# Altium schematic sheet 09 - FPGA POWER.SchDoc
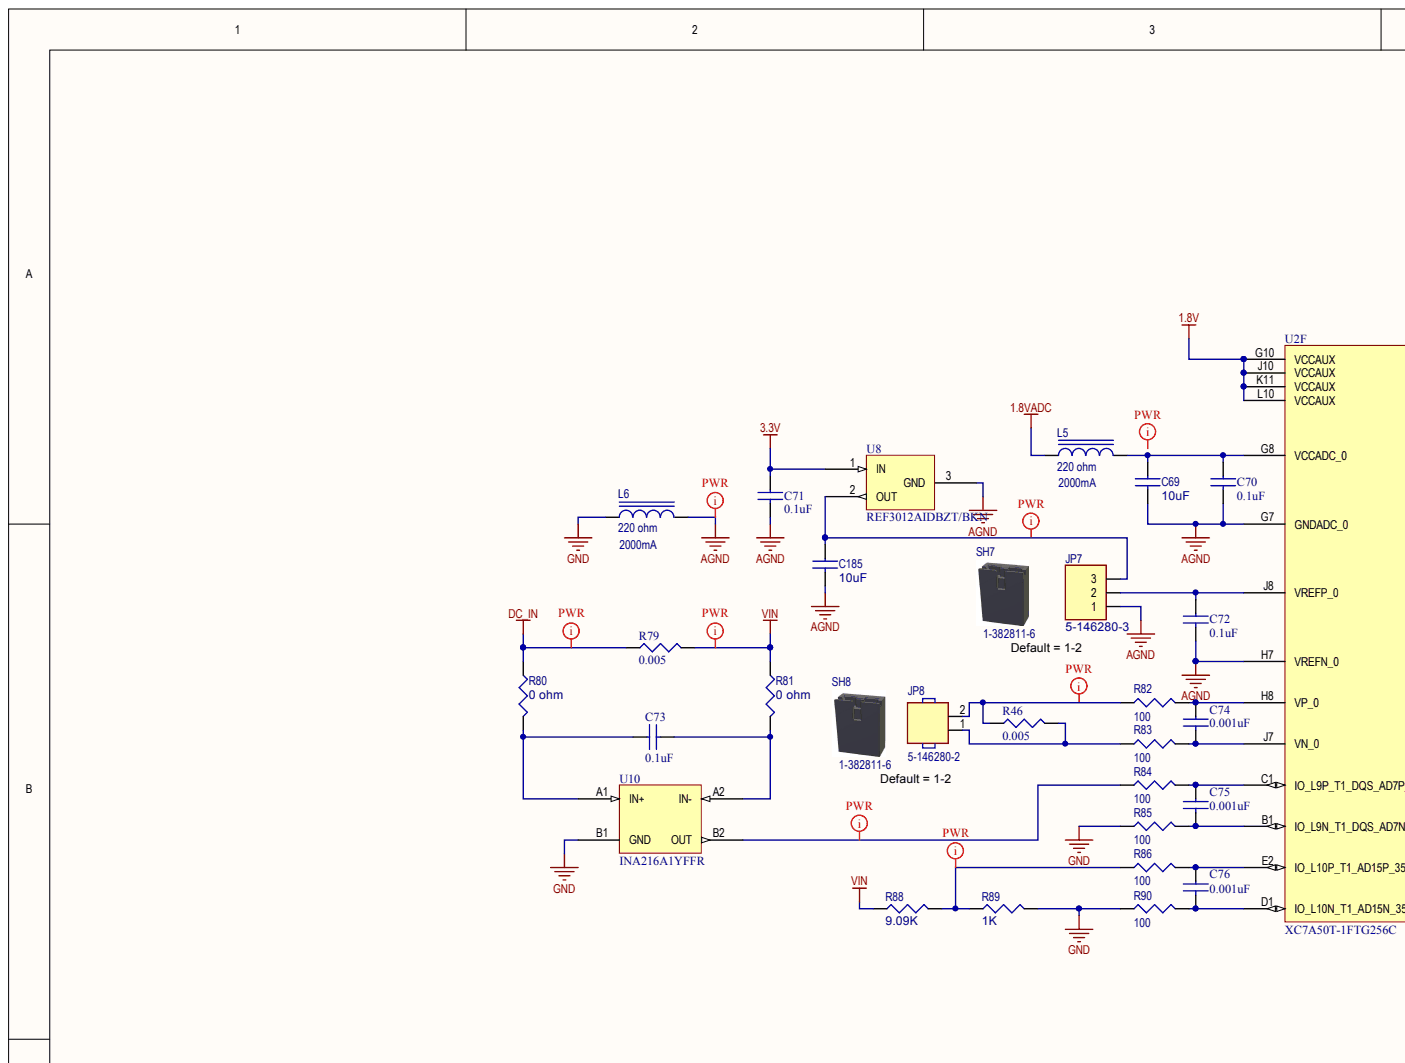
[diagram p0: 09 - FPGA POWER.SchDoc, top left]
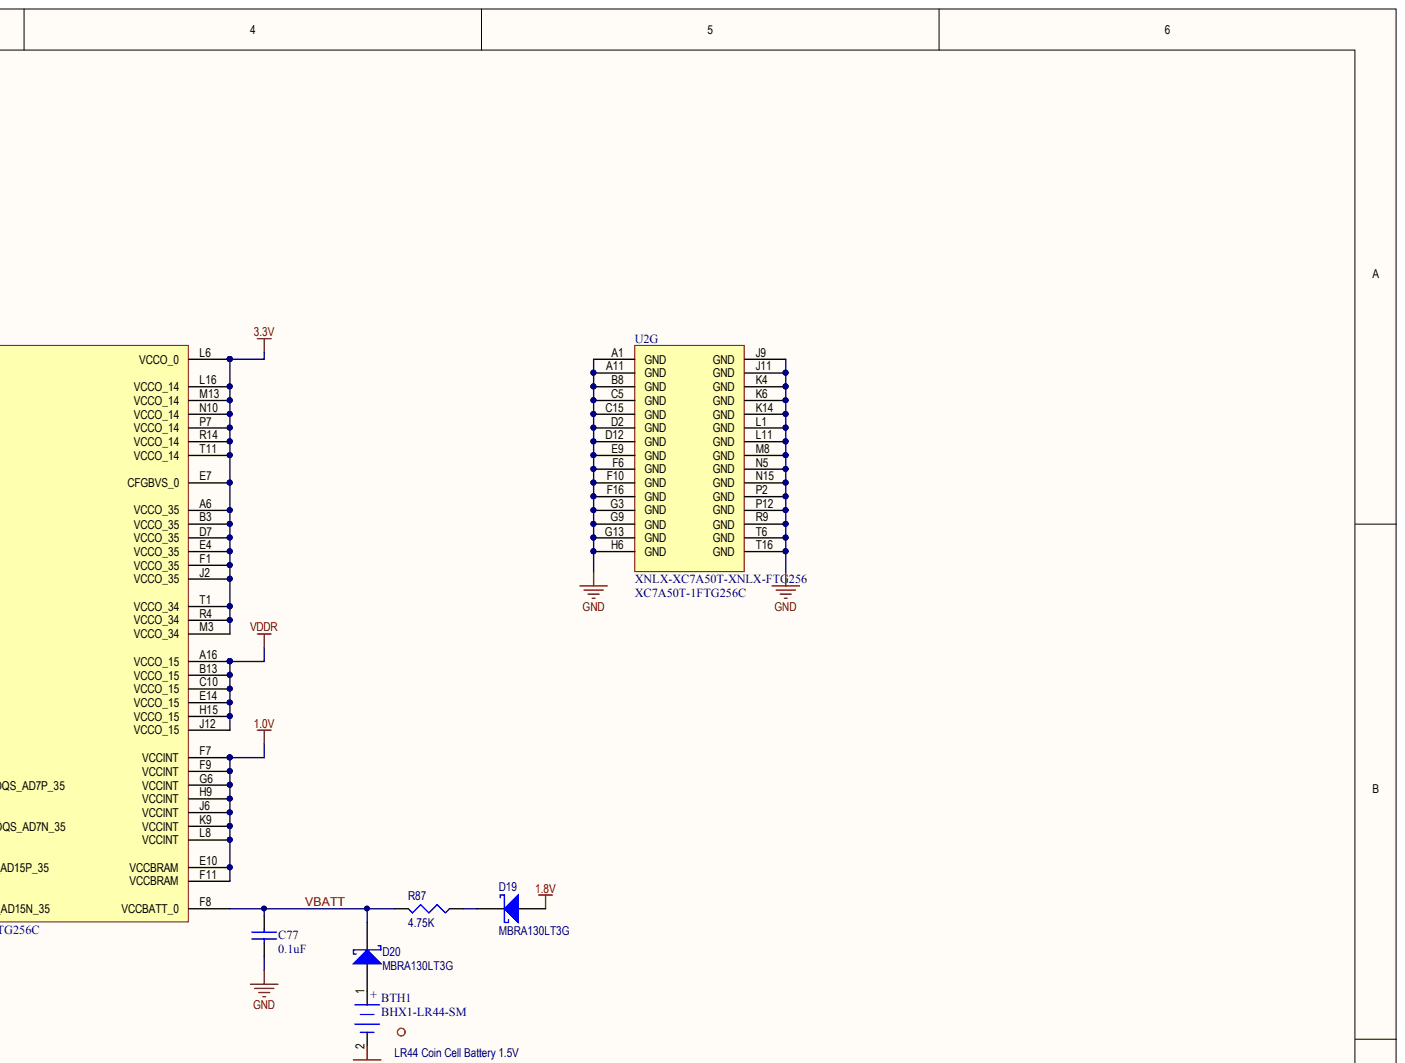
[diagram p1: 09 - FPGA POWER.SchDoc, top right]
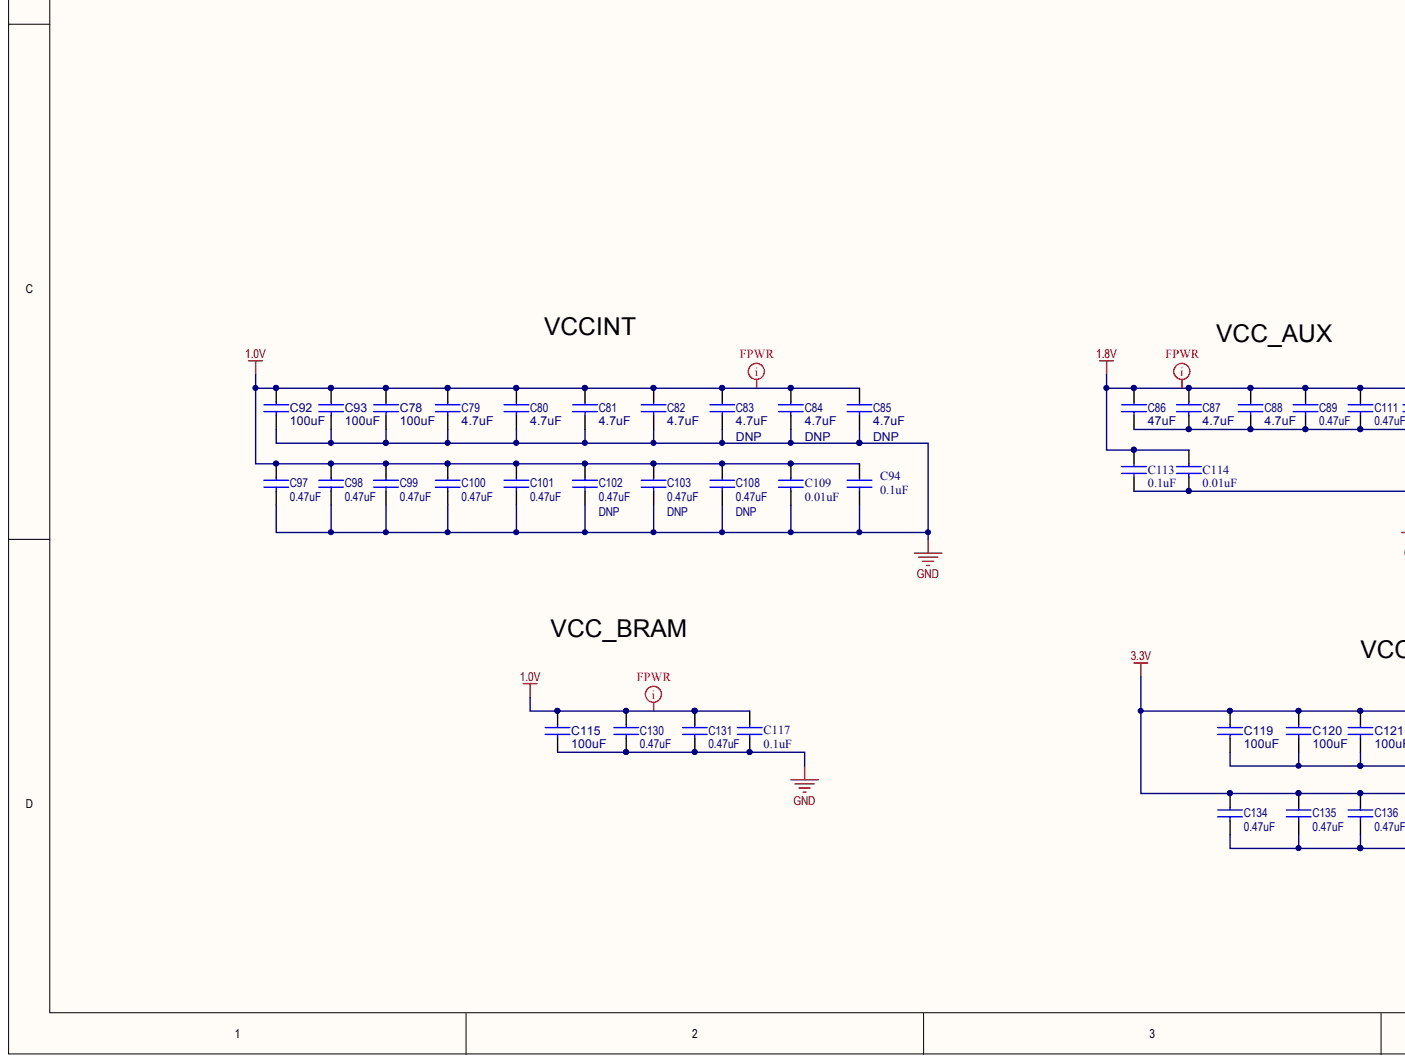
[diagram p2: 09 - FPGA POWER.SchDoc, bottom left]
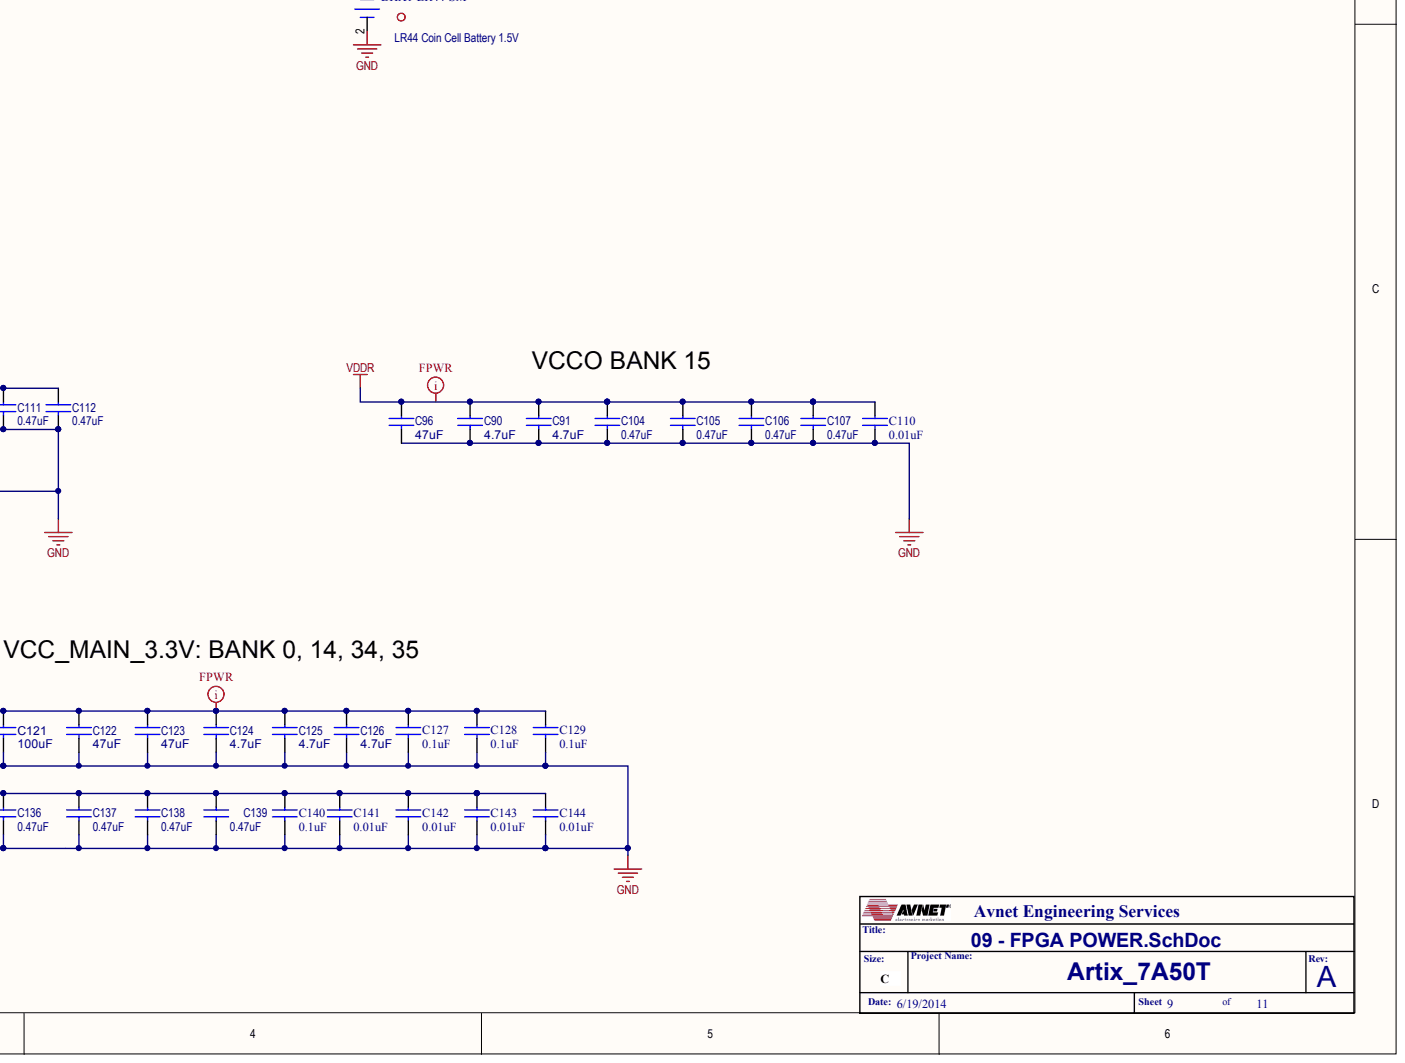
[diagram p3: 09 - FPGA POWER.SchDoc, bottom right]

## Parts
BPH1  LR44 Coin Cell Battery 1.5V
BTH1  BHX1-LR44-SM
C69  10uF
C70  value 0.1uF  (hidden comment: PC522)
C71  value 0.1uF  (hidden comment: PC522)
C72  value 0.1uF  (hidden comment: PC522)
C73  value 0.1uF  (hidden comment: PC522)
C74  value 0.001uF  (hidden comment: 0.001uF 6.3V 0201)
C75  value 0.001uF  (hidden comment: 0.001uF 6.3V 0201)
C76  value 0.001uF  (hidden comment: 0.001uF 6.3V 0201)
C77  value 0.1uF  (hidden comment: PC522)
C78  100uF
C79  value 4.7uF  (hidden comment: 4.8uF)
C80  value 4.7uF  (hidden comment: 4.8uF)
C81  value 4.7uF  (hidden comment: 4.8uF)
C82  value 4.7uF  (hidden comment: 4.8uF)
C83  value 4.7uF  (hidden comment: 4.8uF)
C84  value 4.7uF  (hidden comment: 4.8uF)
C85  value 4.7uF  (hidden comment: 4.8uF)
C86  47uF
C87  value 4.7uF  (hidden comment: 4.8uF)
C88  value 4.7uF  (hidden comment: 4.8uF)
C89  value 0.47uF  (hidden comment: PC475)
C90  value 4.7uF  (hidden comment: 4.8uF)
C91  value 4.7uF  (hidden comment: 4.8uF)
C92  100uF
C93  100uF
C94  value 0.1uF  (hidden comment: PC522)
C96  47uF
C97  value 0.47uF  (hidden comment: PC475)
C98  value 0.47uF  (hidden comment: PC475)
C99  value 0.47uF  (hidden comment: PC475)
C100  value 0.47uF  (hidden comment: PC475)
C101  value 0.47uF  (hidden comment: PC475)
C102  value 0.47uF  (hidden comment: PC475)
C103  value 0.47uF  (hidden comment: PC475)
C104  value 0.47uF  (hidden comment: PC475)
C105  value 0.47uF  (hidden comment: PC475)
C106  value 0.47uF  (hidden comment: PC475)
C107  value 0.47uF  (hidden comment: PC475)
C108  value 0.47uF  (hidden comment: PC475)
C109  value 0.01uF  (hidden comment: PC003)
C110  value 0.01uF  (hidden comment: PC003)
C111  value 0.47uF  (hidden comment: PC475)
C112  value 0.47uF  (hidden comment: PC475)
C113  value 0.1uF  (hidden comment: PC522)
C114  value 0.01uF  (hidden comment: PC003)
C115  100uF
C117  value 0.1uF  (hidden comment: PC522)
C119  100uF
C120  100uF
C121  100uF
C122  47uF
C123  47uF
C124  value 4.7uF  (hidden comment: 4.8uF)
C125  value 4.7uF  (hidden comment: 4.8uF)
C126  value 4.7uF  (hidden comment: 4.8uF)
C127  value 0.1uF  (hidden comment: PC522)
C128  value 0.1uF  (hidden comment: PC522)
C129  value 0.1uF  (hidden comment: PC522)
C130  value 0.47uF  (hidden comment: PC475)
C131  value 0.47uF  (hidden comment: PC475)
C134  value 0.47uF  (hidden comment: PC475)
C135  value 0.47uF  (hidden comment: PC475)
C136  value 0.47uF  (hidden comment: PC475)
C137  value 0.47uF  (hidden comment: PC475)
C138  value 0.47uF  (hidden comment: PC475)
C139  value 0.47uF  (hidden comment: PC475)
C140  value 0.1uF  (hidden comment: PC522)
C141  value 0.01uF  (hidden comment: PC003)
C142  value 0.01uF  (hidden comment: PC003)
C143  value 0.01uF  (hidden comment: PC003)
C144  value 0.01uF  (hidden comment: PC003)
C185  10uF
D19  Device: MBRA130LT3G  (hidden comment: DD004)
D20  Device: MBRA130LT3G  (hidden comment: DD004)
JP7  Device: 5-146280-3  (hidden comment: EH003)
JP8  5-146280-2
L5  value 220 ohm  Max I: 2000mA  (hidden comment: PF009)
L6  value 220 ohm  Max I: 2000mA  (hidden comment: PF009)
R46  value 0.005  (hidden comment: RES 1206 0.005)
R79  value 0.005  (hidden comment: RES 1206 0.005)
R80  0 ohm
R81  0 ohm
R82  value 100  (hidden comment: 0402)
R83  value 100  (hidden comment: 0402)
R84  value 100  (hidden comment: 0402)
R85  value 100  (hidden comment: 0402)
R86  value 100  (hidden comment: 0402)
R87  value 4.75K  (hidden comment: 0402)
R88  9.09K
R89  1K
R90  value 100  (hidden comment: 0402)
SH7  1-382811-6
SH8  1-382811-6
U2  XNLX-XC7A50T-XNLX-FTG256  MFGPN: XC7A50T-1FTG256C  (parts F,G)
U8  MFGPN: REF3012AIDBZT/BKN  (hidden comment: TI REF3012)
U10  MFGPN: INA216A1YFFR  (hidden comment: TI INA216A1)

## Nets
1.0V: C78.1 C79.1 C80.1 C81.1 C82.1 C83.1 C84.1 C85.1 C92.1 C93.1 C94.1 C97.1 C98.1 C99.1 C100.1 C101.1 C102.1 C103.1 C108.1 C109.1 C115.1 C117.1 C130.1 C131.1 U2.E10(VCCBRAM) U2.F7(VCCINT) U2.F9(VCCINT) U2.F11(VCCBRAM) U2.G6(VCCINT) U2.H9(VCCINT) U2.J6(VCCINT) U2.K9(VCCINT) U2.L8(VCCINT)
1.8V: C86.1 C87.1 C88.1 C89.1 C111.1 C112.1 C113.1 C114.1 D19.A U2.G10(VCCAUX) U2.J10(VCCAUX) U2.K11(VCCAUX) U2.L10(VCCAUX)
1.8VADC: L5.1
3.3V: C71.1 C119.1 C120.1 C121.1 C122.1 C123.1 C124.1 C125.1 C126.1 C127.1 C128.1 C129.1 C134.1 C135.1 C136.1 C137.1 C138.1 C139.1 C140.1 C141.1 C142.1 C143.1 C144.1 U2.A6(VCCO_35) U2.B3(VCCO_35) U2.D7(VCCO_35) U2.E4(VCCO_35) U2.E7(CFGBVS_0) U2.F1(VCCO_35) U2.J2(VCCO_35) U2.L6(VCCO_0) U2.L16(VCCO_14) U2.M3(VCCO_34) U2.M13(VCCO_14) U2.N10(VCCO_14) U2.P7(VCCO_14) U2.R4(VCCO_34) U2.R14(VCCO_14) U2.T1(VCCO_34) U2.T11(VCCO_14) U8.1
AGND: C69.2 C70.2 C71.2 C72.2 C185.2 JP7.1 L6.2 U2.G7(GNDADC_0) U2.H7(VREFN_0) U8.3
DC_IN: R79.1 R80.1
GND: BTH1.2 C77.2 C78.2 C79.2 C80.2 C81.2 C82.2 C83.2 C84.2 C85.2 C86.2 C87.2 C88.2 C89.2 C90.2 C91.2 C92.2 C93.2 C94.2 C96.2 C97.2 C98.2 C99.2 C100.2 C101.2 C102.2 C103.2 C104.2 C105.2 C106.2 C107.2 C108.2 C109.2 C110.2 C111.2 C112.2 C113.2 C114.2 C115.2 C117.2 C119.2 C120.2 C121.2 C122.2 C123.2 C124.2 C125.2 C126.2 C127.2 C128.2 C129.2 C130.2 C131.2 C134.2 C135.2 C136.2 C137.2 C138.2 C139.2 C140.2 C141.2 C142.2 C143.2 C144.2 L6.1 R85.1 R89.1 R90.1 U2.A1(GND) U2.A11(GND) U2.B8(GND) U2.C5(GND) U2.C15(GND) U2.D2(GND) U2.D12(GND) U2.E9(GND) U2.F6(GND) U2.F10(GND) U2.F16(GND) U2.G3(GND) U2.G9(GND) U2.G13(GND) U2.H6(GND) U2.J9(GND) U2.J11(GND) U2.K4(GND) U2.K6(GND) U2.K14(GND) U2.L1(GND) U2.L11(GND) U2.M8(GND) U2.N5(GND) U2.N15(GND) U2.P2(GND) U2.P12(GND) U2.R9(GND) U2.T6(GND) U2.T16(GND) U10.B1(GND)
VDDR: C90.1 C91.1 C96.1 C104.1 C105.1 C106.1 C107.1 C110.1 U2.A16(VCCO_15) U2.B13(VCCO_15) U2.C10(VCCO_15) U2.E14(VCCO_15) U2.H15(VCCO_15) U2.J12(VCCO_15)
VIN: R79.2 R81.1 R88.1
VBATT: C77.1 D20.C R87.2 U2.F8(VCCBATT_0)
NetBTH1_1: BTH1.1 D20.A
NetC69_1: C69.1 C70.1 L5.2 U2.G8(VCCADC_0)
NetC72_1: C72.1 JP7.2 U2.J8(VREFP_0)
NetC73_1: C73.1 R80.2 U10.A1(IN+)
NetC73_2: C73.2 R81.2 U10.A2(IN-)
NetC74_1: C74.1 R82.2 U2.H8(VP_0)
NetC74_2: C74.2 R83.2 U2.J7(VN_0)
NetC75_1: C75.1 R84.2 U2.C1(IO_L9P_T1_DQS_AD7P_35)
NetC75_2: C75.2 R85.2 U2.B1(IO_L9N_T1_DQS_AD7N_35)
NetC76_1: C76.1 R86.2 U2.E2(IO_L10P_T1_AD15P_35)
NetC76_2: C76.2 R90.2 U2.D1(IO_L10N_T1_AD15N_35)
NetC185_1: C185.1 JP7.3 U8.2
NetD19_C: D19.C R87.1
NetJP8_1: JP8.1 R46.2 R83.1
NetJP8_2: JP8.2 R46.1 R82.1
NetR84_1: R84.1 U10.B2(OUT)
NetR86_1: R86.1 R88.2 R89.2
N00446:

## Library data of the parts
BPH1 = (no symbol name): MFG Code: ANY; MFGPN: ANY
BTH1 = (no symbol name): MFG Code: TYC; MFGPN: 120591-1; Note: Conn Battery SKT 2 POS 2.54mm Solder RA Thru-Hole 3 Terminal 1 Port Tray
C69 C185 = (no symbol name): PartCode: PC; AVLNo: AVPC-0000086; PkgType: SM; AsyTxt: PC086; Volt: 6.3V; Tol: 20%; Dielectric: X5R; ESR: CAP; MFG Code: AVX; MFGPN: 06036D106MAT2A; Value: 10uF; RoHS: Yes; Status: Preliminary; Device: CAP; ComponentLink1Description: Datasheet; CLASSNAME: ETH_PWR; ComponentLink1URL: C:\AVDBLIB\DATASHEETS\AVX_CCOG.pdf
C70-C73 C77 C94 C113 C117 C127-C129 C140 = (no symbol name): PartCode: PC; MFGPN: 02016D104KAT2A; AVLNo: AVPC-0000522; PkgType: SM; Volt: 10V; Tol: 10%; Dielectric: X5R; Device: CAP; MFG Code: AVX; Status: Preliminary; AsyTxt: PC522; RoHS: Yes; ComponentLink1Description: Datasheet; ComponentLink1URL: C:\AVDBLIB\DATASHEETS\AVX_CCOG.pdf
C74-C76 = (no symbol name): Preferred Part #: PIC ECJ-ZEB0J102K; AES Part #: AVPC-0000091; MFGPN: GRM033R71E102KA01D; Status: Preliminary
C78 C92 C93 C115 C119-C121 = (no symbol name): PartCode: PC; AVLNo: AVPC-0000209; PkgType: SM; AsyTxt: PC209; Volt: 6.3V; Tol: 20%; Dielectric: X5R; MFG Code: MUR; MFGPN: GRM32EF50J107ZE20K; Power: 0; Device: CAP; Value: 100uF; RoHS: Yes; ComponentLink1Description: Datasheet; Availability: 180K-AV; Cost: .41; Size: 9.11; Status: Preliminary; Received: 0; ComponentLink1URL: C:\AVDBLIB\DATASHEETS\GRM31CR60J107ME39.pdf
C79-C85 C87 C88 C90 C91 C124-C126 = (no symbol name): PartCode: PC; AVLNo: AVPC-0000499; PkgType: SM; AsyTxt: PC499; Volt: 6.3V; Tol: 20%; Dielectric: X5R; MFG Code: AVX; MFGPN: GRM155R60J475ME87D; Power: 0; Device: CAP; RoHS: Yes; ComponentLink1Description: Datasheet; Availability: 140K-DK; Cost: .46; Size: 1.9; Status: Preliminary; Received: 0; ComponentLink1URL: C:\AVDBLIB\DATASHEETS\murata_caps_c02e.pdf
  C83-C85: DNP: DNP
C86 C96 C122 C123 = (no symbol name): PartCode: PC; AVLNo: AVPC-0000521; PkgType: SM; Volt: 6.3V; Tol: 20%; Dielectric: X5R; MFG Code: TYC; MFGPN: JMK212BJ476MG-T; Power: 0; AsyTxt: PC521; Device: CAP; Value: 47uF; RoHS: Yes; ComponentLink1Description: Datasheet; Availability: 100K-AV; Cost: .18; Size: 5.42; Status: Preliminary; Received: 0; ComponentLink1URL: C:\AVDBLIB\DATASHEETS\TYC_Caps.pdf
C89 C97-C108 C111 C112 C130 C131 C134-C139 = (no symbol name): PartCode: PC; AVLNo: AVPC-0000529; PkgType: SM; Volt: 6.3V; Tol: 10%; Dielectric: X5R; ESR: CAP; MFG Code: TAI; MFGPN: JMK105BJ474KV-F/BKN; AsyTxt: PC475; RoHS: Yes; Status: Preliminary; Device: CAP; ComponentLink1Description: Datasheet; ComponentLink2Description: MFR Website; ComponentLink1URL: C:\AVDBLIB\DATASHEETS\
  C102 C103 C108: DNP: DNP
C109 C110 C114 C141-C144 = (no symbol name): PartCode: PC; AVLNo: AVPC-0000003; PkgType: SM; Volt: 10V; Tol: 10%; Dielectric: X5R; ESR: CAP; MFG Code: AVX; MFGPN: 0201ZD103KAT2A; AsyTxt: PC003; RoHS: Yes; Status: Preliminary; Device: CAP; ComponentLink1Description: Datasheet; ComponentLink2Description: MFR Website; ComponentLink2URL: www.avx.com; ComponentLink1URL: C:\AVDBLIB\DATASHEETS\AVX_CX5R.pdf
D19 D20 = (no symbol name): PartCode: DD; AVLNo: AVDD-0000004; PkgType: SM; Device Type: Diode; Imax: 1.0A; Vr: 30V; MFG Code: MOT; AsyTxt: DD004; RoHS: Yes; Status: Preliminary; Device Sub Type: MBRA130LT3G; Technology: Schottky; MFGPN: MBRA130LT3G; ComponentLink1Description: Datasheet; ComponentLink1URL: C:\AVDBLIB\DATASHEETS\MBRA130LT3G.PDF
JP7 = (no symbol name): PartCode: EH; AVLNo: AVEH-0000003; PkgType: TH; Device Type: Header; Pitch: 100mil; Col-Row: 3x1; MFG Code: AMP; AsyTxt: EH003; RoHS: Yes; Status: Preliminary; MFGPN: 5-146280-3; ComponentLink1Description: Datasheet; ComponentLink1URL: C:\AVDBLIB\DATASHEETS\146280.pdf
JP8 = (no symbol name): MFG Code: AMP; Note: CONN HEADR BRKWAY .100 2POS STR; MFGPN: 5-146280-2
L5 L6 = (no symbol name): PartCode: PF; AVLNo: AVPF-0000009; PkgType: SM; Test Freq: 100MHz; Tol: 0.25; DCR: 0.045 ohm; Device: FB; MFG Code: MUR; MFGPN: BLM21PG221SN1D; AsyTxt: PF009; RoHS: Yes; Status: Preliminary; ComponentLink1Description: Datasheet; ComponentLink1URL: C:\AVDBLIB\DATASHEETS\L0110S0100BLM21P.pdf
R46 R79 = (no symbol name): MFGPN: CSNL1206FT5L00; MFG: STACKPOLE; PKG: 1206; TOL: 1%
R80 R81 = (no symbol name): PartCode: PR; AVLNo: AVPR-0000027; PkgType: SM; AsyTxt: PR027; Watt: 62mW; Device: RES; MFG Code: DAL; MFGPN: CRCW04020000Z0ED; Value: 0 ohm; RoHS: Yes; Status: Preliminary; ComponentLink1Description: Datasheet; ComponentLink1URL: C:\AVDBLIB\DATASHEETS\Dale_CRCW.pd
R82-R86 R90 = (no symbol name): MFG Code: DAL; MFGPN: CRCW0402100RFKED
R87 = (no symbol name): MFG Code: DAL; MFGPN: CRCW04024K75FKED; Note: Res Thick Film 0402 4.75K Ohm 1% 1/16W ±100ppm/°C Molded SMD SMD Paper T/R
R88 = (no symbol name): PartCode: PR; AVLNo: AVPR-0000xxx; PkgType: SM; AsyTxt: PR094; Watt: 62mW; Tol: 0.01; Device: RES; MFG Code: DAL; MFGPN: CRCW04029K09FKED; Value: 9.09K; RoHS: Yes; Status: Preliminary; ComponentLink1Description: Datasheet; ComponentLink1URL: C:\AVDBLIB\DATASHEETS\Dale_CRCW.pd
R89 = (no symbol name): PartCode: PR; AVLNo: AVPR-0000052; PkgType: SM; AsyTxt: PR052; Watt: 62mW; Tol: 0.01; Device: RES; MFG Code: DAL; MFGPN: CRCW04021K00FKED; Value: 1K; RoHS: Yes; Status: Preliminary; ComponentLink1Description: Datasheet; ComponentLink1URL: C:\AVDBLIB\DATASHEETS\Dale_CRCW.pd
SH7 SH8 = (no symbol name): MFG Code: AMP; Note: SHUNT,ECON,PHBR 15AU,BLACK L/P; MFGPN: 1-382811-6
U2 = (no symbol name): PKG: FBGA256
U8 = (no symbol name): PKG: SOT23-3
U10 = (no symbol name): PKG: WCSP4 BGA
